# Altium schematic sheet Sheet1.SchDoc(Sheet1)
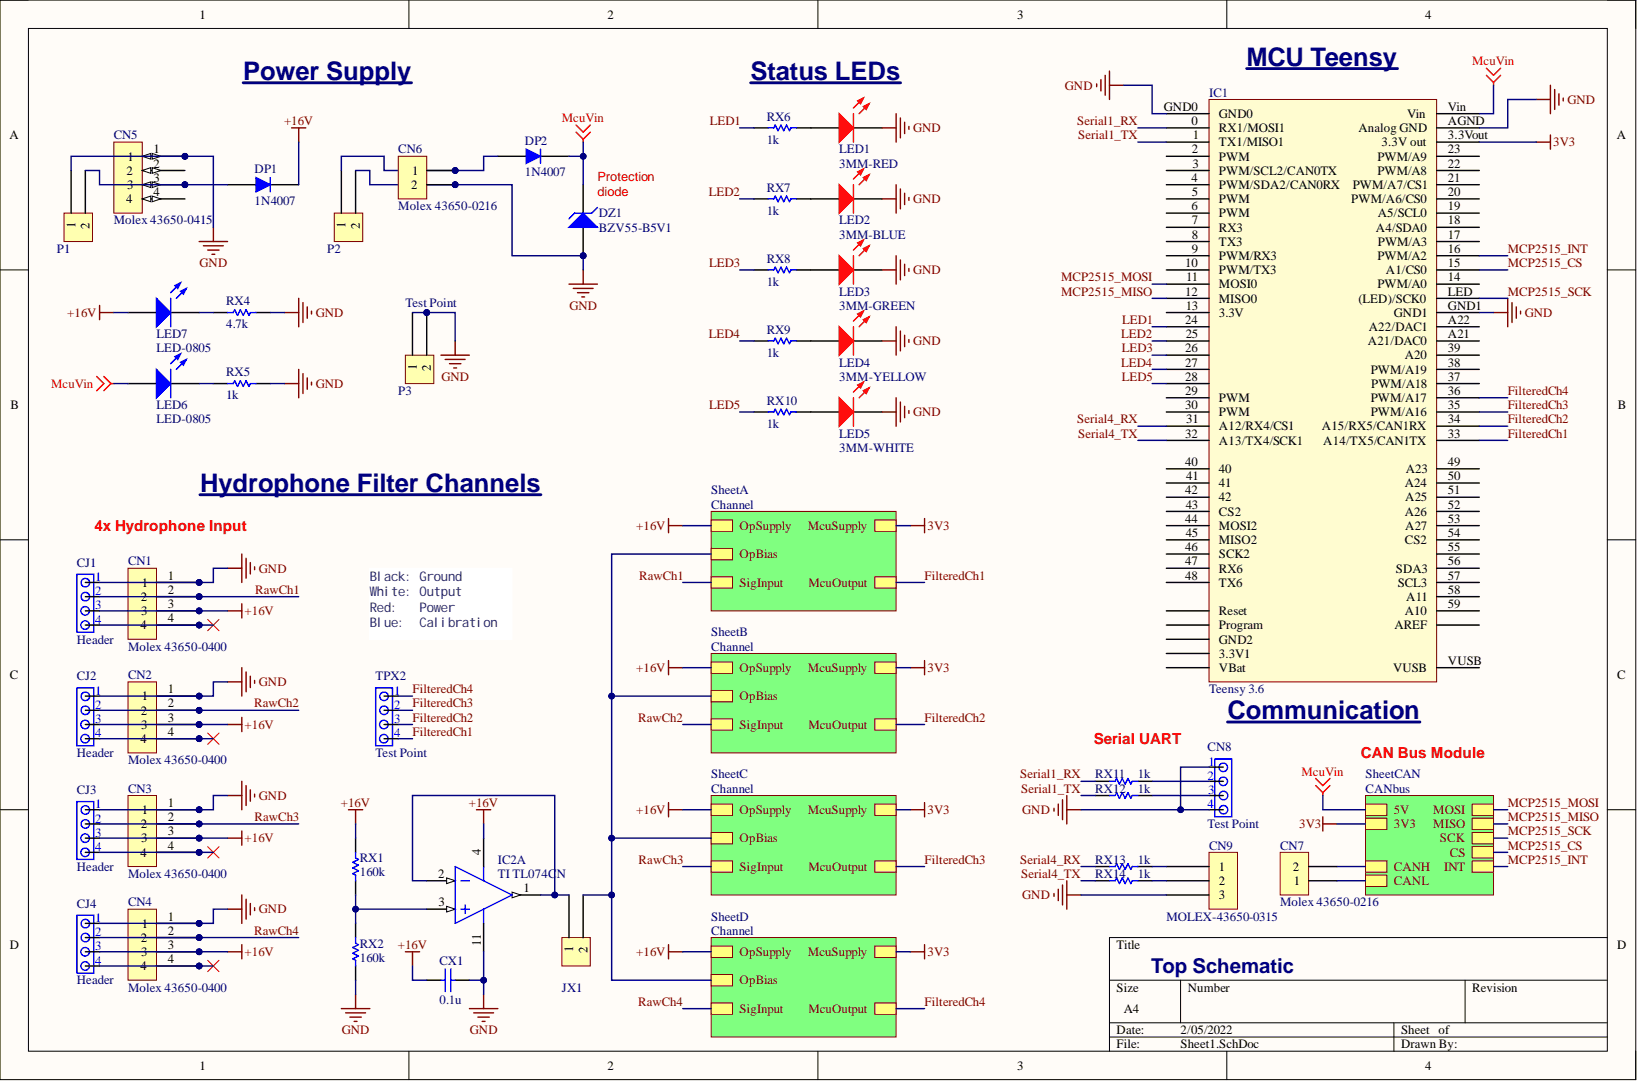
[diagram p0: Sheet1.SchDoc]

## Parts
CJ1  Header  [PCB-0bfjvpkufifbx95u45yw-1]
CJ2  Header  [PCB-0bfjvpkufifbx95u45yw-1]
CJ3  Header  [PCB-0bfjvpkufifbx95u45yw-1]
CJ4  Header  [PCB-0bfjvpkufifbx95u45yw-1]
CN1  Molex 43650-0400
CN2  Molex 43650-0400
CN3  Molex 43650-0400
CN4  Molex 43650-0400
CN5  Molex 43650-0415  Manufacturer Part Number: 43650-0415  [PCB-vm2iqe32ix5ks50y5iwy-1]
CN6  Molex 43650-0216  Manufacturer Part Number: 43650-0216  [PCB-0c70c57ea599d3b7-2]
CN7  Molex 43650-0216  Manufacturer Part Number: 43650-0216  [PCB-0c70c57ea599d3b7-2]
CN8  Test Point  [PCB-0bfjvpkufifbx95u45yw-1]
CN9  MOLEX-43650-0315
CX1  0.1u
DP1  1N4007  [PCB-kzeakw2snxr54lsx6p55-1]
DP2  1N4007  [PCB-kzeakw2snxr54lsx6p55-1]
DZ1  BZV55-B5V1  [PCB-lgxrfu0czqrr4p3mixw0-1]
IC1  Teensy 3.6
IC2  TI TL074CN  Manufacturer Part Number: TL074CN  [PCB-j0oe16ub0lpj0vrdtzdc-1]  (part A)
JX1  Header 2pin  [PCB-uaae8rj7siexws8oa76t-2]  (value not drawn)
LED1  3MM-RED  [PCB-8fnm1gqdpse16arx63h0-3]
LED2  3MM-BLUE  [PCB-8fnm1gqdpse16arx63h0-3]
LED3  3MM-GREEN  [PCB-8fnm1gqdpse16arx63h0-3]
LED4  3MM-YELLOW  [PCB-8fnm1gqdpse16arx63h0-3]
LED5  3MM-WHITE  [PCB-8fnm1gqdpse16arx63h0-3]
LED6  LED-0805  [PCB-9ifma0oh53wy532w7hco-2]
LED7  LED-0805  [PCB-9ifma0oh53wy532w7hco-2]
P1  Header 2pin  [PCB-uaae8rj7siexws8oa76t-2]  (value not drawn)
P2  Header 2pin  [PCB-uaae8rj7siexws8oa76t-2]  (value not drawn)
P3  Test Point  [PCB-uaae8rj7siexws8oa76t-2]
RX1  160k  [PCB-0002-00356-1]
RX2  160k  [PCB-0002-00356-1]
RX4  4.7k  [PCB-0002-00356-1]
RX5  1k  [PCB-0002-00356-1]
RX6  1k  [PCB-0002-00356-1]
RX7  1k  [PCB-0002-00356-1]
RX8  1k  [PCB-0002-00356-1]
RX9  1k  [PCB-0002-00356-1]
RX10  1k  [PCB-0002-00356-1]
RX11  1k  [PCB-0002-00356-1]
RX12  1k  [PCB-0002-00356-1]
RX13  1k  [PCB-0002-00356-1]
RX14  1k  [PCB-0002-00356-1]
TPX2  Test Point  [PCB-0bfjvpkufifbx95u45yw-1]

## Nets
3V3: IC1.3.3Vout(3.3V_out)
+16V: CJ1.3 CJ2.3 CJ3.3 CJ4.3 CN1.3 CN2.3 CN3.3 CN4.3 CX1.1 DP1.2 IC2.4 LED7.2 RX1.2
GND: CJ1.1 CJ2.1 CJ3.1 CJ4.1 CN1.1 CN2.1 CN3.1 CN4.1 CN5.1 CN6.2 CN8.1 CN8.4 CN9.3 CX1.2 DZ1.1 IC1.AGND(Analog_GND) IC1.GND0 IC1.GND1 IC2.11 LED1.2 LED2.2 LED3.2 LED4.2 LED5.2 P1.1 P2.2 P3.1 P3.2 RX2.1 RX4.1 RX5.1
McuVin: DP2.2 DZ1.2 IC1.Vin LED6.2
FilteredCh1: IC1.33(A14/TX5/CAN1TX) TPX2.4
FilteredCh2: IC1.34(A15/RX5/CAN1RX) TPX2.3
FilteredCh3: IC1.35(PWM/A16) TPX2.2
FilteredCh4: IC1.36(PWM/A17) TPX2.1
LED1: IC1.24 RX6.2
LED2: IC1.25 RX7.2
LED3: IC1.26 RX8.2
LED4: IC1.27 RX9.2
LED5: IC1.28 RX10.2
MCP2515_CS: IC1.15(A1/CS0)
MCP2515_INT: IC1.16(PWM/A2)
MCP2515_MISO: IC1.12(MISO0)
MCP2515_MOSI: IC1.11(MOSI0)
MCP2515_SCK: IC1.LED((LED)/SCK0)
RawCh1: CJ1.2 CN1.2
RawCh2: CJ2.2 CN2.2
RawCh3: CJ3.2 CN3.2
RawCh4: CJ4.2 CN4.2
Serial1_RX: IC1.0(RX1/MOSI1) RX11.2
Serial1_TX: IC1.1(TX1/MISO1) RX12.2
Serial4_RX: IC1.31(A12/RX4/CS1) RX13.2
Serial4_TX: IC1.32(A13/TX4/SCK1) RX14.2
NetCJ1_4: CJ1.4 CN1.4
NetCJ2_4: CJ2.4 CN2.4
NetCJ3_4: CJ3.4 CN3.4
NetCJ4_4: CJ4.4 CN4.4
NetCN5_2: CN5.2
NetCN5_3: CN5.3 DP1.1 P1.2
NetCN5_4: CN5.4
NetCN6_1: CN6.1 DP2.1 P2.1
NetCN7_1: CN7.1
NetCN7_2: CN7.2
NetCN8_2: CN8.2 RX11.1
NetCN8_3: CN8.3 RX12.1
NetCN9_1: CN9.1 RX13.1
NetCN9_2: CN9.2 RX14.1
NetIC1_2: IC1.2(PWM)
NetIC1_3: IC1.3(PWM/SCL2/CAN0TX)
NetIC1_3.3V1: IC1.3.3V1
NetIC1_4: IC1.4(PWM/SDA2/CAN0RX)
NetIC1_5: IC1.5(PWM)
NetIC1_6: IC1.6(PWM)
NetIC1_7: IC1.7(RX3)
NetIC1_8: IC1.8(TX3)
NetIC1_9: IC1.9(PWM/RX3)
NetIC1_10: IC1.10(PWM/TX3)
NetIC1_13: IC1.13(3.3V)
NetIC1_14: IC1.14(PWM/A0)
NetIC1_17: IC1.17(PWM/A3)
NetIC1_18: IC1.18(A4/SDA0)
NetIC1_19: IC1.19(A5/SCL0)
NetIC1_20: IC1.20(PWM/A6/CS0)
NetIC1_21: IC1.21(PWM/A7/CS1)
NetIC1_22: IC1.22(PWM/A8)
NetIC1_23: IC1.23(PWM/A9)
NetIC1_29: IC1.29(PWM)
NetIC1_30: IC1.30(PWM)
NetIC1_37: IC1.37(PWM/A18)
NetIC1_38: IC1.38(PWM/A19)
NetIC1_39: IC1.39(A20)
NetIC1_40: IC1.40
NetIC1_41: IC1.41
NetIC1_42: IC1.42
NetIC1_43: IC1.43(CS2)
NetIC1_44: IC1.44(MOSI2)
NetIC1_45: IC1.45(MISO2)
NetIC1_46: IC1.46(SCK2)
NetIC1_47: IC1.47(RX6)
NetIC1_48: IC1.48(TX6)
NetIC1_49: IC1.49(A23)
NetIC1_50: IC1.50(A24)
NetIC1_51: IC1.51(A25)
NetIC1_52: IC1.52(A26)
NetIC1_53: IC1.53(A27)
NetIC1_54: IC1.54(CS2)
NetIC1_55: IC1.55
NetIC1_56: IC1.56(SDA3)
NetIC1_57: IC1.57(SCL3)
NetIC1_58: IC1.58(A11)
NetIC1_59: IC1.59(A10)
NetIC1_A21: IC1.A21(A21/DAC0)
NetIC1_A22: IC1.A22(A22/DAC1)
NetIC1_AREF: IC1.AREF
NetIC1_GND2: IC1.GND2
NetIC1_Program: IC1.Program
NetIC1_Reset: IC1.Reset
NetIC1_VBat: IC1.VBat
NetIC1_VUSB: IC1.VUSB
NetIC2_1: IC2.1 IC2.2 JX1.1
NetIC2_3: IC2.3 RX1.1 RX2.2
NetJX1_2: JX1.2
NetLED1_1: LED1.1 RX6.1
NetLED2_1: LED2.1 RX7.1
NetLED3_1: LED3.1 RX8.1
NetLED4_1: LED4.1 RX9.1
NetLED5_1: LED5.1 RX10.1
NetLED6_1: LED6.1 RX5.2
NetLED7_1: LED7.1 RX4.2

## Library data of the parts
CJ1-CJ4 CN8 TPX2 = CMP-ce480524f220118f-1: 5-146274-4 ; ROHS ; TYCO ; AMPMODU Breakaway Heade rs 4P HEADER TIN single r ow; ELV Compliant: Compliant; Component Kind: Standard; Contact Material: Phosphor Bronze; Contact Plating: Tin, Tin over Nickel; Flammability Rating: UL 94 V-0; Housing Color: Black; Library Name: My Personal Space; Lifecycle Status: Active; Manufacturer Part Number: 5-146274-4; Mounting Style: PCB; Octopart URL: http://octopart.com/5-146274-4-te+connectivity+%2F+amp-40245518; OctopartID: ce480524f220118f; Orientation: Vertical; RoHS: Compliant
CN1-CN4 = CMP-2b85e28b82b9f442-5: Conn Power HDR 4 POS 3mm Solder RA Thru-Hole 4 Terminal 1 Port Micro-Fit 3.0????? Tray; Component Kind: Standard; Library Name: My Personal Space
CN5 = CMP-82ba4bb42b575d7d-1: Conn; Rect; Header; 4 Cir; PCB; MicroFit 3.0; Vertical; Single; SMT; w/Peg; Tin; Current Rating: 5.00 A; Gender: Male; Glow Wire Compliant: Compliant; Mated Height: 6.98 mm; Number of Circuits: 4; Pin Pitch: 3.00 mm; Color: Black; Component Kind: Standard; Contact Material: Brass; Contact Plating: Tin; Flammability Rating: UL 94 V-0; Halogen Free Status: Halogen Free; Housing Color: Black; Housing Material: Plastic; Library Name: My Personal Space; Lifecycle Status: Active; Mounting Style: Through Hole, Vertical, PCB; Number of Contacts: 4; Number of Positions: 4; Number of Rows: 1; Octopart URL: https://octopart.com/43650-0415-molex-298123; OctopartID: 82ba4bb42b575d7d; Operating Temperature: 105 °C (max); Orientation: Straight; Packaging: Tray; REACH SVHC Compliance: No SVHC; RoHS: Compliant; Size-Height: 9.90 mm; Size-Length: 15.7 mm; Size-Width: 4.37 mm
CN6 CN7 = CMP-0c70c57ea599d3b7-6: Conn Power HDR 2 POS 3mm Solder ST Thru-Hole 2 Terminal 1 Port Micro-Fit 3.0™ Tray; Current Rating: 5.00 A; Gender: Male; Glow Wire Compliant: Compliant; Insulation Resistance: 1.00 GΩ; Lead-Free Status: Lead Free; Mated Height: 17.3 mm; Number of Circuits: 2; Pin Pitch: 3.00 mm; Voltage Rating (DC): 250 V; Color: Black; Component Kind: Standard; Contact Material: Brass; Contact Plating: Gold; Flammability Rating: UL 94 V-0; Halogen Free Status: Low Halogen; Housing Color: Black; Housing Material: Thermoplastic; Library Name: My Personal Space; Lifecycle Status: Active; Mounting Style: Through Hole, PCB, Vertical; Number of Contacts: 2; Number of Positions: 2; Number of Rows: 1; Octopart URL: http://octopart.com/43650-0216-molex-298127; OctopartID: 0c70c57ea599d3b7; Orientation: Vertical; Packaging: Tray; RoHS: Compliant; Size-Height: 9.90 mm; Size-Length: 9.65 mm; Size-Width: 4.37 mm
CN9 = CMP-of6dx0b68ulk1pyxyhr2-1: Component Kind: Standard; CustomComponentID: of6dx0b68ulk1pyxyhr2; Library Name: My Personal Space
CX1 = CMP-773ebe35ab3ba8f9-5: Capacitor: ceramic; MLCC; 100nF; 50VDC; X7R; ±20%; SMD; 0805; MPN: C0805C104M5RACTU; Capacitance: 0.1 uF; Component Kind: Standard; Library Name: My Personal Space; Package: 0805
DP1 DP2 = CMP-9114309-20: 1N4007 Series 1000 V 1 A Axial Lead Standard Recovery Rectifier - CASE 59-10; Manufacturer Part Number: 1N4007G; Breakdown Voltage: 1.00 kV (max); Current Rating: 1.00 A; Forward Voltage: 1.10 V; Lead-Free Status: Lead Free; Output Current: 1.00 A (max); Voltage Rating (DC): 1.00 kV; Case/Package: DO-204; Component Kind: Standard; Halogen Free Status: Halogen Free; Library Name: My Personal Space; Lifecycle Status: Active; Mounting Style: Axial; Number of Pins: 2; Octopart URL: https://octopart.com/1n4007g-on+semiconductor-6031; OctopartID: ffb836b2cd51207f; Operating Temperature: -65.0 °C to 175 °C; Packaging: Bulk; Polarity: Standard; REACH SVHC Compliance: No SVHC; RoHS: Compliant
DZ1 = CMP-ee91273a99d63156-2: BZV55 Series 5.1 V ±5 % 500 mW Surface Mount Voltage Regulator Diode - SOD-80C; Manufacturer Part Number: BZV55-C5V1,115; Lead-Free Status: Lead Free; Component Kind: Standard; Library Name: My Personal Space; Lifecycle Status: Active; Mounting Style: Surface Mount; Number of Pins: 2; Octopart URL: http://octopart.com/bzv55-c5v1%2C115-nxp+semiconductors-10572321; OctopartID: ee91273a99d63156; Packaging: Tape & Reel (TR), Cut Tape (CT); REACH SVHC Compliance: No SVHC; RoHS: Compliant
IC1 = CMP-ff3832a4d40b20ff-34: Teensy 3.6 complete; Component Kind: Standard; Library Name: My Personal Space
IC2 = CMP-1321015-6: Quad Low-Noise JFET-Input General-Purpose Operational Amplifier, 7 to 36 V, DIP (N14); Manufacturer: Texas Instruments; Bandwidth: 3000000.0 Hz; Input Bias Current: 6.5e-11 A; Input Offset Drift: 1.8e-05 V/K; Lead-Free Status: Lead Free; Maximum Supply Voltage: 36VDC; Model/Series: TL07x; Number of Channels: 4; Rise Time: 1e-07 s; Slew Rate: 13.0 V/μs; Supply Current: 0.0014 A; Case/Package: DIP; Component Kind: Standard; Gain Bandwidth Product: 3000000.0 Hz; Library Name: My Personal Space; Lifecycle Status: Active; Mounting Style: Through Hole; Number of Circuits: 4; Number of Pins: 14; Octopart Url: https://octopart.com/tl074cn-texas+instruments-4198; OctopartID: 9f7d5677aa7d40ba; Packaging: Tube; RoHS: Compliant
JX1 P1-P3 = CMP-74e0bf2ff245ab27-13: Connector, Through Hole, Header, 2, 2.54 mm 2pin; Manufacturer Part Number: 826926-2; ELV Compliant: Compliant; Gender: Male; Lead-Free Status: Lead Free; Number of Contacts: 2; Pin Pitch: 2.54 mm; Component Kind: Standard; Flammability Rating: UL 94 V-0; Housing Color: Green; Housing Material: Thermoplastic; Library Name: My Personal Space; Mounting Style: PCB; OctopartID: 74e0bf2ff245ab27; Orientation: Vertical; Packaging: Bulk; REACH SVHC Compliance: No SVHC; RoHS: Compliant
LED1-LED5 = CMP-cd2f0425acb312e8-17: LED; Red; 30mcd; 0.126In.Dia.; T-1; 150mA; 2V; 635nm; 60deg; Diffused; 85 to 85degC; Manufacturer Part Number: SSL-LX3044LID; Forward Voltage: 2.00 V; Lead-Free Status: Lead Free; Luminous Intensity: 30.0 mcd; Viewing Angle: 60 °; Color: Red; Component Kind: Standard; Lens Color: Red; Lens Type: Diffused; Library Name: My Personal Space; Mounting Style: Through Hole; Number of Pins: 2; Octopart URL: http://octopart.com/ssl-lx3044lid-lumex-17658; OctopartID: cd2f0425acb312e8; Packaging: Bulk; RoHS: Compliant; Size-Diameter: 3.00 mm; Size-Height: 4.80 mm; Wavelength: 635 nm
LED6 LED7 = CMP-1071566-10: 598-8110-107F, Dialight, SMD LED 0805 Package, Red Single color SOLD IN INCREMENTS OF 4000. (REEL); Case/Package (SI): 2012; Forward Voltage: 2.20 V; Lead-Free Status: Lead Free; Lens Type: Non-Diffused; Luminous Intensity: 40.0 mcd; Viewing Angle: 140 °; Wavelength: 635 nm, 642 nm; Case/Package: 0805; Color: Red; Component Kind: Standard; Lens Color: Red; Library Name: My Personal Space; Manufacturer Part Number: 598-8110-107F; Mounting Style: Surface Mount, Vertical; Number of Pins: 2; Octopart URL: https://octopart.com/598-8110-107f-dialight-868569; OctopartID: bcc375c4d97c6304; Operating Temperature: 85.0 °C (max); Packaging: Cut Tape (CT); REACH SVHC Compliance: No SVHC; RoHS: Compliant; Size-Height: 700 µm; Size-Length: 2.00 mm; Size-Width: 1.25 mm
RX1 RX2 RX4-RX14 = CMP-7553bc7ad099aa94-1: Res Thick Film 0805 100K Ohm 5% 1/8W ±200ppm/°C Molded SMD SMD Paper Tape on Plastic Reel; Manufacturer Part Number: CR0805-JW-104ELF; Case/Package (SI): 2012; Power Rating: 125 mW; Resistance: 100 kΩ; Temperature Coefficient: -200 ppm/°C to 200 ppm/°C; Voltage Rating (DC): 150 V; Case/Package: 0805; Component Kind: Standard; Library Name: My Personal Space; Mounting Style: Surface Mount; Octopart URL: https://octopart.com/cr0805-jw-104elf-bourns-2326700; OctopartID: 7553bc7ad099aa94; Packaging: Cut Tape (CT); REACH SVHC Compliance: No SVHC; Resistance Tolerance: ±5%; RoHS: Compliant; Size-Length: 2.01 mm; Size-Thickness: 508 µm; Size-Width: 1.24 mm
